# Altium schematic sheet Raijin_Main.SchDoc
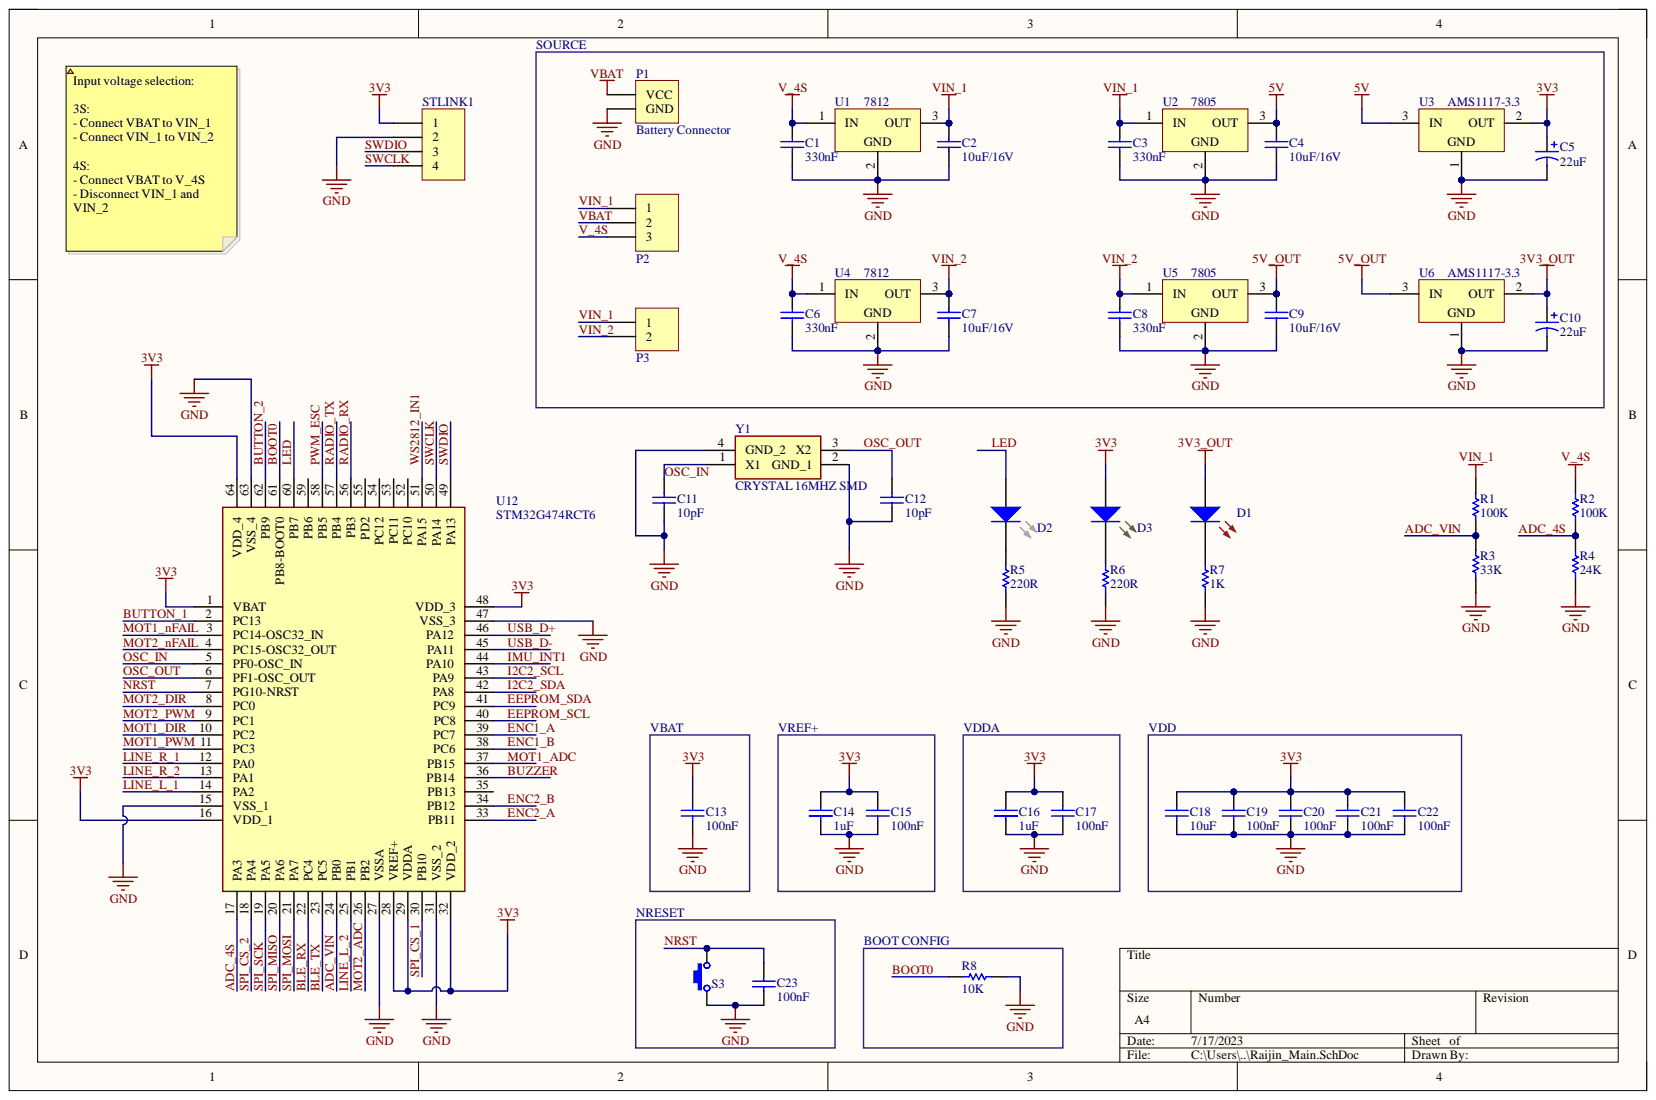
[diagram p0: Raijin_Main.SchDoc]

## Parts
C1  330nF  [Capacitor-0603]
C2  10uF/16V  [CAPACITOR-0805]
C3  330nF  [Capacitor-0603]
C4  10uF/16V  [CAPACITOR-0805]
C5  22uF  [CAPPM6032X280N]
C6  330nF  [Capacitor-0603]
C7  10uF/16V  [CAPACITOR-0805]
C8  330nF  [Capacitor-0603]
C9  10uF/16V  [CAPACITOR-0805]
C10  22uF  [CAPPM6032X280N]
C11  10pF  [Capacitor-0603]
C12  10pF  [Capacitor-0603]
C13  100nF  [Capacitor-0603]
C14  1uF  [Capacitor-0603]
C15  100nF  [Capacitor-0603]
C16  1uF  [Capacitor-0603]
C17  100nF  [Capacitor-0603]
C18  10uF  [CAPACITOR-0805]
C19  100nF  [Capacitor-0603]
C20  100nF  [Capacitor-0603]
C21  100nF  [Capacitor-0603]
C22  100nF  [Capacitor-0603]
C23  100nF  [Capacitor-0603]
D1  LED RED 0603  [LED 0603]  (value not drawn)
D2  LED WHITE  [LED1411 (3528)]  (value not drawn)
D3  LED GREEN  [LED1411 (3528)]  (value not drawn)
P1  Battery Connector  [BATTERY CONNECTOR]
P2  Header 1x3  [HDR MALE 1x3 - JUMPER]  (value not drawn)
P3  Header 1x2  [HDR MALE 1X2 - JUMPER]  (value not drawn)
R1  100K  [RESISTOR 0603]
R2  100K  [RESISTOR 0603]
R3  33K  [RESISTOR 0603]
R4  24K  [RESISTOR 0603]
R5  220R  [RESISTOR 0603]
R6  220R  [RESISTOR 0603]
R7  1K  [RESISTOR 0603]
R8  10K  [RESISTOR 0603]
S3  SPST-NO  [TACTILE SWITCH 3x6x2.5]  (value not drawn)
STLINK1  Header 1x4  [HDR MALE 1x4]  (value not drawn)
U1  Name: 7812  [D-PAK]  (hidden comment: LM7812)
U2  Name: 7805  [D-PAK]  (hidden comment: LM7805)
U3  AMS1117-3.3  [SOT223 - AMS1117]
U4  Name: 7812  [D-PAK]  (hidden comment: LM7812)
U5  Name: 7805  [D-PAK]  (hidden comment: LM7805)
U6  AMS1117-3.3  [SOT223 - AMS1117]
U12  STM32G474RCT6  [QFP50P1200X1200X160-64N]
Y1  CRYSTAL 16MHZ SMD  [ABM10W240000MHZ8K1ZT3]

## Nets
3V3: C5.1 C13.2 C14.2 C15.2 C16.2 C17.2 C18.2 C19.2 C20.2 C21.2 C22.2 D3.1 STLINK1.1 U3.2 U12.1(VBAT) U12.16(VDD_1) U12.28(VREF+) U12.29(VDDA) U12.32(VDD_2) U12.48(VDD_3) U12.64(VDD_4)
3V3_OUT: C10.1 D1.1 U6.2
5V: C4.2 U2.3 U3.3
5V_OUT: C9.2 U5.3 U6.3
GND: C1.1 C2.1 C3.1 C4.1 C5.2 C6.1 C7.1 C8.1 C9.1 C10.2 C11.2 C12.2 C13.1 C14.1 C15.1 C16.1 C17.1 C18.1 C19.1 C20.1 C21.1 C22.1 C23.1 P1.2 R3.1 R4.1 R5.2 R6.2 R7.1 R8.2 S3.1 STLINK1.2 U1.2 U2.2 U3.1 U4.2 U5.2 U6.1 U12.15(VSS_1) U12.27(VSSA) U12.31(VSS_2) U12.47(VSS_3) U12.63(VSS_4) Y1.2(GND_1) Y1.4(GND_2)
ADC_4S: R2.1 R4.2 U12.17(PA3)
ADC_VIN: R1.1 R3.2 U12.24(PB0)
BLE_RX: U12.22(PC4)
BLE_TX: U12.23(PC5)
BOOT0: R8.1 U12.61(PB8-BOOT0)
BUTTON_1: U12.2(PC13)
BUTTON_2: U12.62(PB9)
BUZZER: U12.36(PB14)
EEPROM_SCL: U12.40(PC8)
EEPROM_SDA: U12.41(PC9)
ENC1_A: U12.39(PC7)
ENC1_B: U12.38(PC6)
ENC2_A: U12.33(PB11)
ENC2_B: U12.34(PB12)
I2C2_SCL: U12.43(PA9)
I2C2_SDA: U12.42(PA8)
IMU_INT1: U12.44(PA10)
LED: D2.1 U12.60(PB7)
LINE_L_1: U12.14(PA2)
LINE_L_2: U12.25(PB1)
LINE_R_1: U12.12(PA0)
LINE_R_2: U12.13(PA1)
MOT1_ADC: U12.37(PB15)
MOT1_DIR: U12.10(PC2)
MOT1_nFAIL: U12.3(PC14-OSC32_IN)
MOT1_PWM: U12.11(PC3)
MOT2_ADC: U12.26(PB2)
MOT2_DIR: U12.8(PC0)
MOT2_nFAIL: U12.4(PC15-OSC32_OUT)
MOT2_PWM: U12.9(PC1)
NRST: C23.2 S3.2 U12.7(PG10-NRST)
OSC_IN: C11.1 U12.5(PF0-OSC_IN) Y1.1(X1)
OSC_OUT: C12.1 U12.6(PF1-OSC_OUT) Y1.3(X2)
PWM_ESC: U12.58(PB5)
RADIO_RX: U12.56(PB3)
RADIO_TX: U12.57(PB4)
SPI_CS_1: U12.30(PB10)
SPI_CS_2: U12.18(PA4)
SPI_MISO: U12.20(PA6)
SPI_MOSI: U12.21(PA7)
SPI_SCK: U12.19(PA5)
SWCLK: STLINK1.4 U12.50(PA14)
SWDIO: STLINK1.3 U12.49(PA13)
USB_D+: U12.46(PA12)
USB_D-: U12.45(PA11)
V_4S: C1.2 C6.2 P2.3 R2.2 U1.1 U4.1
VBAT: P1.1 P2.2
VIN_1: C2.2 C3.2 P2.1 P3.1 R1.2 U1.3 U2.1
VIN_2: C7.2 C8.2 P3.2 U4.3 U5.1
WS2812_IN1: U12.51(PA15)
NetD1_2: D1.2 R7.2
NetD2_2: D2.2 R5.1
NetD3_2: D3.2 R6.1

Not connected: U12 35(PB13) 52(PC10) 53(PC11) 54(PC12) 55(PD2) 59(PB6)

## Library data of the parts
C1 C3 C6 C8 = CAP 330nF: Capacitor Cer 330nF 50V; Manufacturer Part Number: IMP14925; Capacitance: 0.1uF; Capacitance Tolerance: +80%, -20%; Capacitor Terminals: Radial Leaded; Dielectric Characteristic: Y5; Product Range: MCFY Series; SVHC: No SVHC (15-Jun-2015); Voltage Rating: 50; Capacitance Tolerance ±: +80%, -20%; Component Kind: Standard; ComponentLink1Description: http://loja.multcomercial.com.br/capacitor-ceramico-disco-100kpf-50v-104-100k-100nf-0-1uf-cod-1351.html; ComponentLink1URL: http://loja.multcomercial.com.br/capacitor-ceramico-disco-100kpf-50v-104-100k-100nf-0-1uf-cod-1351.html; Lead Spacing: 5; Manufacturer: -; Operating Temperature Max: 85; Operating Temperature Min: -25; Operating Temperature Range: -25°C to +85°C<!--FANDB--><div class="features-and-benefits-container"><div class="features-and-benefits-description"><span class="features-benefits-value">The MCFY series from Multicomp are radial leaded ceramic disc capacitors. The capacitance values ranges from 6800pF to 0.22?F.</span></div><div class="features-and-benefits-list"><ul class="features-and-benefits-list-list"><li class="features-and-benefits-list-item">Large capacitance in small size</li><li class="features-and-benefits-list-item">Rated voltage of 50V</li><li class="features-and-benefits-list-item">80%/-20% tolerance</li><li class="features-and-benefits-list-item">Operating temperature range -25°C to +85; Packaging: Each; Pricing 1: 1=0.10 (BRL); RoHS: YES; Stock 1: 115809; Supplier 1: Mult Comercial; Supplier Part Number 1: Capacitor Cerâmico Disco 100Kpf/50V (104/100K/100nF/0.1uF) cód. 1351; Library Name: RaijinLib.SchLib
C2 C4 C7 C9 C18 = CAP CER 10UF 16V X5R 0805: Manufacturer: AVX Corporation; Manufacturer Part Number: 0805YD106KAT2A; Capacitance: 10µF; Tolerance: ±10%; Applications: General Purpose; Category: Capacitors; Component Kind: Standard; ComponentLink1Description: http://datasheets.avx.com/cx5r.pdf; ComponentLink1URL: http://datasheets.avx.com/cx5r.pdf; Description: CAP CER 10UF 16V X5R 0805; Features: -; Height - Seated (Max): -; Lead Spacing: -; Lead Style: -; Mounting Type: Surface Mount, MLCC; Operating Temperature: -55°C ~ 85°C; Package / Case: 0805 (2012 Metric); Packaging: Cut Tape (CT); Part Status: Active; Pricing 1: 1=0.18, 10=0.124, 25=0.0908, 50=0.0742, 100=0.0627, 250=0.05116, 500=0.0429, 1000=0.03795 (USD); Ratings: -; RoHS: RoHS Compliant; Size / Dimension: 0.079" L x 0.049" W (2.01mm x 1.25mm); Stock 1: 198871; Supplier 1: Digi-Key; Supplier Part Number 1: 478-5165-1-ND; Temperature Coefficient: X5R; Thickness (Max): 0.055" (1.40mm); Voltage - Rated: 16V; Library Name: RaijinLib.SchLib
C5 C10 = CAP 22uF Tantalo: CAP ELE RAD 10uF 16V; Manufacturer Part Number: IMP1295; Capacitance Tolerance: ± 20%; Capacitor Terminals: Radial Leaded; Leakage Current: 6; Lifetime @ Temperature: 2000 hours @ 85; Product Range: MCGPR Series; SVHC: No SVHC (15-Jun-2015); Voltage Rating: 16; Capacitance: 10uF; Capacitance Tolerance ±: ± 20%; Component Kind: Standard; ComponentLink1Description: http://loja.multcomercial.com.br/capacitor-eletrolitico-10uf-16v.html; ComponentLink1URL: http://loja.multcomercial.com.br/capacitor-eletrolitico-10uf-16v.html; Diameter: 5; ESR: -; Height: 11; Lead Spacing: 2; Manufacturer: -; Operating Temperature Max: 85; Operating Temperature Min: -40; Operating Temperature Range: -40°C to +85°C<!--FANDB--><div class="features-and-benefits-container"><div class="features-and-benefits-description"><span class="features-benefits-value">The Multicomp MCGPR series capacitors are general purpose, radial leaded polarized aluminium electrolytic capacitors. MCGPR series parts cover broad range of voltage ratings and capacitance values.</span></div><div class="features-and-benefits-list"><ul class="features-and-benefits-list-list"><li class="features-and-benefits-list-item">Capacitance value ranges from 0.1µF to 22000µF for 6.3VDC to 100VDC</li><li class="features-and-benefits-list-item">Capacitance value ranges from 0.47µF to 820µF for 160VDC to 450VDC</li><li class="features-and-benefits-list-item">Tolerance of ±20%</li><li class="features-and-benefits-list-item">Endurance of 2000h at 85°C</li><li class="features-and-benefits-list-item">Available in -40°C to 85°C and -25°C to 85; Packaging: Each; Pricing 1: 1=0.50 (BRL); RoHS: YES; Stock 1: 33826; Supplier 1: Mult Comercial; Supplier Part Number 1: Capacitor Eletrolítico 10uF/16V; Library Name: RaijinLib.SchLib
C11 C12 = CAP 10pF: Capacitor Cer 10pF; Manufacturer Part Number: IMP14925; Capacitance Tolerance: +80%, -20%; Capacitor Terminals: Radial Leaded; Dielectric Characteristic: Y5; Product Range: MCFY Series; SVHC: No SVHC (15-Jun-2015); Voltage Rating: 50; Capacitance Tolerance ±: +80%, -20%; Component Kind: Standard; ComponentLink1Description: http://loja.multcomercial.com.br/capacitor-ceramico-disco-100kpf-50v-104-100k-100nf-0-1uf-cod-1351.html; ComponentLink1URL: http://loja.multcomercial.com.br/capacitor-ceramico-disco-100kpf-50v-104-100k-100nf-0-1uf-cod-1351.html; Lead Spacing: 5; Manufacturer: -; Operating Temperature Max: 85; Operating Temperature Min: -25; Operating Temperature Range: -25°C to +85°C<!--FANDB--><div class="features-and-benefits-container"><div class="features-and-benefits-description"><span class="features-benefits-value">The MCFY series from Multicomp are radial leaded ceramic disc capacitors. The capacitance values ranges from 6800pF to 0.22?F.</span></div><div class="features-and-benefits-list"><ul class="features-and-benefits-list-list"><li class="features-and-benefits-list-item">Large capacitance in small size</li><li class="features-and-benefits-list-item">Rated voltage of 50V</li><li class="features-and-benefits-list-item">80%/-20% tolerance</li><li class="features-and-benefits-list-item">Operating temperature range -25°C to +85; Packaging: Each; Pricing 1: 1=0.10 (BRL); RoHS: YES; Stock 1: 115809; Supplier 1: Mult Comercial; Supplier Part Number 1: Capacitor Cerâmico Disco 100Kpf/50V (104/100K/100nF/0.1uF) cód. 1351; Library Name: RaijinLib.SchLib
C13 C15 C17 C19-C23 = CAP 100NF 50V: Capacitor Cer 100nF 50V; Manufacturer Part Number: IMP14925; Capacitance: 0.1uF; Capacitance Tolerance: +80%, -20%; Capacitor Terminals: Radial Leaded; Dielectric Characteristic: Y5; Product Range: MCFY Series; SVHC: No SVHC (15-Jun-2015); Voltage Rating: 50; Capacitance Tolerance ±: +80%, -20%; Component Kind: Standard; ComponentLink1Description: http://loja.multcomercial.com.br/capacitor-ceramico-disco-100kpf-50v-104-100k-100nf-0-1uf-cod-1351.html; ComponentLink1URL: http://loja.multcomercial.com.br/capacitor-ceramico-disco-100kpf-50v-104-100k-100nf-0-1uf-cod-1351.html; Lead Spacing: 5; Manufacturer: -; Operating Temperature Max: 85; Operating Temperature Min: -25; Operating Temperature Range: -25°C to +85°C<!--FANDB--><div class="features-and-benefits-container"><div class="features-and-benefits-description"><span class="features-benefits-value">The MCFY series from Multicomp are radial leaded ceramic disc capacitors. The capacitance values ranges from 6800pF to 0.22?F.</span></div><div class="features-and-benefits-list"><ul class="features-and-benefits-list-list"><li class="features-and-benefits-list-item">Large capacitance in small size</li><li class="features-and-benefits-list-item">Rated voltage of 50V</li><li class="features-and-benefits-list-item">80%/-20% tolerance</li><li class="features-and-benefits-list-item">Operating temperature range -25°C to +85; Packaging: Each; Pricing 1: 1=0.10 (BRL); RoHS: YES; Stock 1: 115809; Supplier 1: Mult Comercial; Supplier Part Number 1: Capacitor Cerâmico Disco 100Kpf/50V (104/100K/100nF/0.1uF) cód. 1351; Library Name: RaijinLib.SchLib
C14 C16 = CAP CER 1UF 50V 0603: Multilayer Ceramic Capacitors MLCC - SMD/SMT 1uF 50Volts X5R +/-10% 0603 STD; Manufacturer Part Number: UMK107BJ105KA-T; Brand: Taiyo Yuden; Class: Class 2; Qualification: AEC-Q200; Tolerance: 10 %; Type: Industrial/Automotive and Safety; Voltage Rating DC: 50 VDC; Capacitance: 1 uF; Case Code - in: 0603; Case Code - mm: 1608; Category: Multilayer Ceramic Capacitors MLCC - SMD/SMT; Component Kind: Standard; ComponentLink1Description: http://www.mouser.com/ds/2/396/mlcc_all_e-541559.pdf; ComponentLink1URL: http://www.mouser.com/ds/2/396/mlcc_all_e-541559.pdf; Dielectric: X5R; Height: 0.8 mm; Length: 1.6 mm; Manufacturer: Taiyo Yuden; Maximum Operating Temperature: + 85 C; Minimum Operating Temperature: - 55 C; Minimum Order Quantity: 1; Package / Case: 0603 (1608 metric); Packaging: Reel; Pricing 1: 1=0.512, 10=0.187, 100=0.101, 500=0.072, 1000=0.053, 4000=0.053, 8000=0.038, 12000=0.034, 24000=0.032 (AUD); Product: General Type MLCCs; Reeling: True; RoHS: RoHS Compliant; RoHS - Mouser: Y; Series: M; Stock 1: 123303; Supplier 1: Mouser; Supplier Part Number 1: 1uF; Termination: -; Termination Style: SMD/SMT; Width: 0.8 mm; Library Name: RaijinLib.SchLib
D1 = LED RED 0603: LED; Manufacturer_Name: ROHM Semiconductor; Manufacturer_Part_Number: SML-D12U1WT86; Component Kind: Standard; Datasheet Link: https://componentsearchengine.com/Datasheets/1/SML-D12U1WT86.pdf; Height: 0.65mm; Mouser Part Number: 755-SML-D12U1WT86; Mouser Price/Stock: https://www.mouser.co.uk/ProductDetail/ROHM-Semiconductor/SML-D12U1WT86?qs=M3jcYzEJUdEySuGkbjHEtQ%3D%3D; Library Name: RaijinLib.SchLib
D2 = LED WHITE: Standard LEDs - SMD White TOPLED Black Surface; Manufacturer: OSRAM; Manufacturer Part Number: LW TWTG.BB-BYBZ; Luminous Intensity Typ: 2000; Component Kind: Standard; ComponentLink1Description: http://loja.multcomercial.com.br/led-3mm-vermelho-difuso-l-314hd-paralight.html; ComponentLink1URL: http://loja.multcomercial.com.br/led-3mm-vermelho-difuso-l-314hd-paralight.html; ComponentLink2Description: Mouser; ComponentLink2URL: https://br.mouser.com/ProductDetail/OSRAM-Opto-Semiconductors/LW-TWTGBB-BYBZ-2B12C3-Z486?qs=sGAEpiMZZMseGfSY3csMkdgyOOAg6kv20s3Ceu2Hg6%2Fq9qYEXiqoDQ%3D%3D; Library Name: RaijinLib.SchLib
D3 = LED GREEN: Standard LEDs - SMD White TOPLED Black Surface; Manufacturer: Wurth Elektronik; Manufacturer Part Number: 150141GS73113; Luminous Intensity Typ: 2500; Component Kind: Standard; ComponentLink3Description: MOUSER2; ComponentLink3URL: https://br.mouser.com/ProductDetail/Wurth-Elektronik/150141GS73113?qs=sGAEpiMZZMseGfSY3csMkdgyOOAg6kv2zYAUfQmngkdzXM%252Bl%252BPVrNQ%3D%3D; Library Name: RaijinLib.SchLib
P2 = Header 1x3: Headers & Wire Housings 40Pin 2mm Spacing Single Row Hdrs, 5pc; Manufacturer Part Number: IMP10327; Brand: Cromax; Mounting Angle: Vertical; Number of Positions: 40 Position; Accessory Type: -; Category: Headers & Wire Housings; Component Kind: Standard; ComponentLink1Description: http://loja.multcomercial.com.br/barra-de-pinos-simples-1x40-macho-bmo40-1e.html; ComponentLink1URL: http://loja.multcomercial.com.br/barra-de-pinos-simples-1x40-macho-bmo40-1e.html; Contact Gender: Pin (Male); Contact Plating: Gold; Contact Type: -; Manufacturer: Cromax; Minimum Order Quantity: 1; Mounting Style: Straight Pin; Number of Rows: 1 Row; Pitch: 2 mm; Pricing 1: 1=1.00, 5=0.20 (BRL); Product: Headers; Product Category: Accessories; Reeling: False; RoHS: RoHS Compliant; RoHS - Mouser: Y; Row Spacing: -; Stock 1: 14; Supplier 1: Mult Comercial; Supplier Part Number 1: Barra de Pinos Simples 1x40 Macho BMO40-1E; Termination Style: Through Hole; Type: Breakaway; Library Name: RaijinLib.SchLib
P3 = Header 1x2: Headers & Wire Housings 40Pin 2mm Spacing Single Row Hdrs, 5pc; Manufacturer Part Number: IMP10327; Brand: Cromax; Mounting Angle: Vertical; Number of Positions: 40 Position; Accessory Type: -; Category: Headers & Wire Housings; Component Kind: Standard; ComponentLink1Description: http://loja.multcomercial.com.br/barra-de-pinos-simples-1x40-macho-bmo40-1e.html; ComponentLink1URL: http://loja.multcomercial.com.br/barra-de-pinos-simples-1x40-macho-bmo40-1e.html; Contact Gender: Pin (Male); Contact Plating: Gold; Contact Type: -; Manufacturer: Cromax; Minimum Order Quantity: 1; Mounting Style: Straight Pin; Number of Rows: 1 Row; Pitch: 2 mm; Pricing 1: 1=1.00, 5=0.20 (BRL); Product: Headers; Product Category: Accessories; Reeling: False; RoHS: RoHS Compliant; RoHS - Mouser: Y; Row Spacing: -; Stock 1: 14; Supplier 1: Mult Comercial; Supplier Part Number 1: Barra de Pinos Simples 1x40 Macho BMO40-1E; Termination Style: Through Hole; Type: Breakaway; Library Name: RaijinLib.SchLib
R1 R2 = RES 100K 5%: Category: Resistors; Component Kind: Standard; ComponentLink1Description: http://www.farnell.com/datasheets/1678796.pdf; ComponentLink1URL: http://www.farnell.com/datasheets/1678796.pdf; ComponentLink2Description: http://industrial.panasonic.com/www-cgi/jvcr13pz.cgi?E+PZ+3+AOA0001+ERJ3GEYJ103V+7+WW; ComponentLink2URL: http://industrial.panasonic.com/www-cgi/jvcr13pz.cgi?E+PZ+3+AOA0001+ERJ3GEYJ103V+7+WW; Resistance (Ohms): 100k; Library Name: RaijinLib.SchLib
R3 = RES 33K 5%: Component Kind: Standard; ComponentLink1Description: http://www.farnell.com/datasheets/1678796.pdf; ComponentLink1URL: http://www.farnell.com/datasheets/1678796.pdf; ComponentLink2Description: http://industrial.panasonic.com/www-cgi/jvcr13pz.cgi?E+PZ+3+AOA0001+ERJ3GEYJ103V+7+WW; ComponentLink2URL: http://industrial.panasonic.com/www-cgi/jvcr13pz.cgi?E+PZ+3+AOA0001+ERJ3GEYJ103V+7+WW; Library Name: RaijinLib.SchLib
R4 = RES 24K 5%: Component Kind: Standard; ComponentLink1Description: http://www.farnell.com/datasheets/1678796.pdf; ComponentLink1URL: http://www.farnell.com/datasheets/1678796.pdf; ComponentLink2Description: http://industrial.panasonic.com/www-cgi/jvcr13pz.cgi?E+PZ+3+AOA0001+ERJ3GEYJ103V+7+WW; ComponentLink2URL: http://industrial.panasonic.com/www-cgi/jvcr13pz.cgi?E+PZ+3+AOA0001+ERJ3GEYJ103V+7+WW; Library Name: RaijinLib.SchLib
R5 R6 = RES 220R 5%_1: Manufacturer: Ty-Ohm; Manufacturer Part Number: CR25; Power (Watts): 0.25W, 1/4W; Resistance (Ohms): 470R; Temperature Coefficient: 0/ -500ppm/°C; Tolerance: ±5%; Category: Resistors; Component Kind: Standard; ComponentLink1Description: http://loja.multcomercial.com.br/resistor-de-1-4-de-watt-cr25-5-de-tolerancia.html; ComponentLink1URL: http://loja.multcomercial.com.br/resistor-de-1-4-de-watt-cr25-5-de-tolerancia.html; Composition: Carbon Film; Features: Flame Retardant Coating, Safety; Height: -; Number of Terminations: 2; Operating Temperature: -55°C ~ 155°C; Package / Case: Axial; Packaging: Cut Tape (CT); Pricing 1: 1=0.20 (BRL); RoHS: RoHS Compliant; Size / Dimension: 0.091" Dia x 0.256" L (2.30mm x 6.50mm); Stock 1: 617543; Supplier 1: Mult Comercial; Supplier Device Package: Axial; Supplier Part Number 1: Resistor de Filme de Carbono 1/4 de Watt CR25 5% de tolerância - 0.22R Ω à 10M Ω; Library Name: RaijinLib.SchLib
R7 = RES 1K 5%: Manufacturer: Ty-Ohm; Manufacturer Part Number: CR25; Power (Watts): 0.25W, 1/4W; Temperature Coefficient: 0/ -500ppm/°C; Tolerance: ±5%; Category: Resistors; Component Kind: Standard; ComponentLink1Description: http://loja.multcomercial.com.br/resistor-de-1-4-de-watt-cr25-5-de-tolerancia.html; ComponentLink1URL: http://loja.multcomercial.com.br/resistor-de-1-4-de-watt-cr25-5-de-tolerancia.html; Composition: Carbon Film; Features: Flame Retardant Coating, Safety; Height: -; Number of Terminations: 2; Operating Temperature: -55°C ~ 155°C; Package / Case: Axial; Packaging: Cut Tape (CT); Pricing 1: 1=0.20 (BRL); Resistance (Ohms): ?; RoHS: RoHS Compliant; Size / Dimension: 0.091" Dia x 0.256" L (2.30mm x 6.50mm); Stock 1: 617543; Supplier 1: Mult Comercial; Supplier Device Package: Axial; Supplier Part Number 1: Resistor de Filme de Carbono 1/4 de Watt CR25 5% de tolerância - 0.22R Ω à 10M Ω; Library Name: RaijinLib.SchLib
R8 = RES 10K 5%: Manufacturer: PANASONIC ELECTRONIC COMPONENTS; Manufacturer Part Number: ERJ-3GEYJ103V; Power (Watts): 0.1W, 1/10W; Temperature Coefficient: ±200ppm/°C; Tolerance: ±5%; Category: Resistors; Component Kind: Standard; ComponentLink1Description: http://www.farnell.com/datasheets/1678796.pdf; ComponentLink1URL: http://www.farnell.com/datasheets/1678796.pdf; ComponentLink2Description: http://industrial.panasonic.com/www-cgi/jvcr13pz.cgi?E+PZ+3+AOA0001+ERJ3GEYJ103V+7+WW; ComponentLink2URL: http://industrial.panasonic.com/www-cgi/jvcr13pz.cgi?E+PZ+3+AOA0001+ERJ3GEYJ103V+7+WW; Composition: Thick Film; Features: Automotive AEC-Q200; Height: 0.022" (0.55mm); Number of Terminations: 2; Operating Temperature: -55°C ~ 155°C; Package / Case: 0603 (1608 Metric); Packaging: Tape & Reel (TR); Resistance (Ohms): 10k; RoHS: YES; Size / Dimension: 0.063" L x 0.031" W (1.60mm x 0.80mm); Supplier Device Package: 0603; Library Name: RaijinLib.SchLib
S3 = Switch Tactile 3x6x2.5: Manufacturer: Panasonic Electronic Components; Manufacturer Part Number: EVQ-PAC07K; Actuator Height off PCB, from Pins: 7.00mm; Actuator Orientation: Top Actuated; Actuator Type: Standard; Contact Rating @ Voltage: 0.02A @ 15VDC; Illumination: Non-Illuminated; Mechanical Life: 100,000 Cycles; Operating Force: 100gf; Outline: 6.00mm x 6.00mm; Switch Function: Off-Mom; Switch Travel: 0.25mm; Actuator Material: -; Category: Switches; Circuit: SPST-NO; Component Kind: Standard; ComponentLink1Description: http://industrial.panasonic.com/cdbs/www-data/pdf/ATK0000/ATK0000CE10.pdf; ComponentLink1URL: http://industrial.panasonic.com/cdbs/www-data/pdf/ATK0000/ATK0000CE10.pdf; Features: -; Illumination Type, Color: -; Illumination Voltage (Nominal): -; Ingress Protection: -; Mounting Type: Through Hole; Operating Temperature: -20°C ~ 70°C; Packaging: Bulk; Part Status: Active; Pricing 1: 1=0.27, 10=0.254, 25=0.2168, 50=0.1896, 100=0.176, 250=0.15572, 500=0.14894, 1000=0.12186, 5000=0.10832 (USD); RoHS: RoHS Compliant; Stock 1: 19805; Supplier 1: Digi-Key; Supplier Part Number 1: P8011S-ND; Termination Style: PC Pin; Library Name: RaijinLib.SchLib
STLINK1 = Header 1x4: Headers & Wire Housings 40Pin 2mm Spacing Single Row Hdrs, 5pc; Manufacturer Part Number: IMP10327; Brand: Cromax; Mounting Angle: Vertical; Number of Positions: 40 Position; Accessory Type: -; Category: Headers & Wire Housings; Component Kind: Standard; ComponentLink1Description: http://loja.multcomercial.com.br/barra-de-pinos-simples-1x40-macho-bmo40-1e.html; ComponentLink1URL: http://loja.multcomercial.com.br/barra-de-pinos-simples-1x40-macho-bmo40-1e.html; Contact Gender: Pin (Male); Contact Plating: Gold; Contact Type: -; Manufacturer: Cromax; Minimum Order Quantity: 1; Mounting Style: Straight Pin; Number of Rows: 1 Row; Pitch: 2 mm; Pricing 1: 1=1.00, 5=0.20 (BRL); Product: Headers; Product Category: Accessories; Reeling: False; RoHS: RoHS Compliant; RoHS - Mouser: Y; Row Spacing: -; Stock 1: 14; Supplier 1: Mult Comercial; Supplier Part Number 1: Barra de Pinos Simples 1x40 Macho BMO40-1E; Termination Style: Through Hole; Type: Breakaway; Library Name: RaijinLib.SchLib
U1 U4 = MCP7812: Component Kind: Standard; Supplier 1: Mouser; Supplier Part Number 1: 926-LM7805CT/NOPB; Library Name: RaijinLib.SchLib
U12 = STM32G474RCT6: Integrated Circuit; Manufacturer_Name: STMicroelectronics; Component Kind: Standard; Datasheet Link: https://www.mouser.it/datasheet/2/389/dm00431551-1799305.pdf; Height: 1.6mm; Manufacturer_Part_Number: STM32G474RCT6; Mouser Part Number: 511-STM32G474RCT6; Mouser Price/Stock: https://www.mouser.co.uk/ProductDetail/STMicroelectronics/STM32G474RCT6?qs=uwxL4vQweFOU%2FtVbMPY7ow%3D%3D; Library Name: RaijinLib.SchLib
Y1 = CRYSTAL 16MHZ SMD: CRYSTAL 16MHZ; Manufacturer_Name: ABRACON; Manufacturer_Part_Number: ABM10W-16.0000MHZ-4-B1U-T3; Arrow Part Number: ABM10W-16.0000MHZ-4-B1U-T3; Arrow Price/Stock: https://www.arrow.com/en/products/abm10w-16.0000mhz-4-b1u-t3/abracon?region=nac; Component Kind: Standard; Datasheet Link: https://www.abracon.com/Resonators/ABM10W.pdf; Height: 0.6mm; Mouser Part Number: 815-10W16-4B1UT; Mouser Price/Stock: https://www.mouser.co.uk/ProductDetail/ABRACON/ABM10W-16.0000MHZ-4-B1U-T3?qs=5aG0NVq1C4x2nD%252BLlu9wCg%3D%3D; Library Name: RaijinLib.SchLib
P1 = Battery Connector: Component Kind: Standard; Library Name: RaijinLib.SchLib
U2 U5 = LM7805: Component Kind: Standard; Supplier 1: Mouser; Supplier Part Number 1: 926-LM7805CT/NOPB; Library Name: RaijinLib.SchLib
U3 U6 = AMS1117-3.3: Component Kind: Standard; Library Name: RaijinLib.SchLib
